# Altium schematic sheet OpenBLC_TPS62152.SchDoc(OpenBLC_TPS62152)
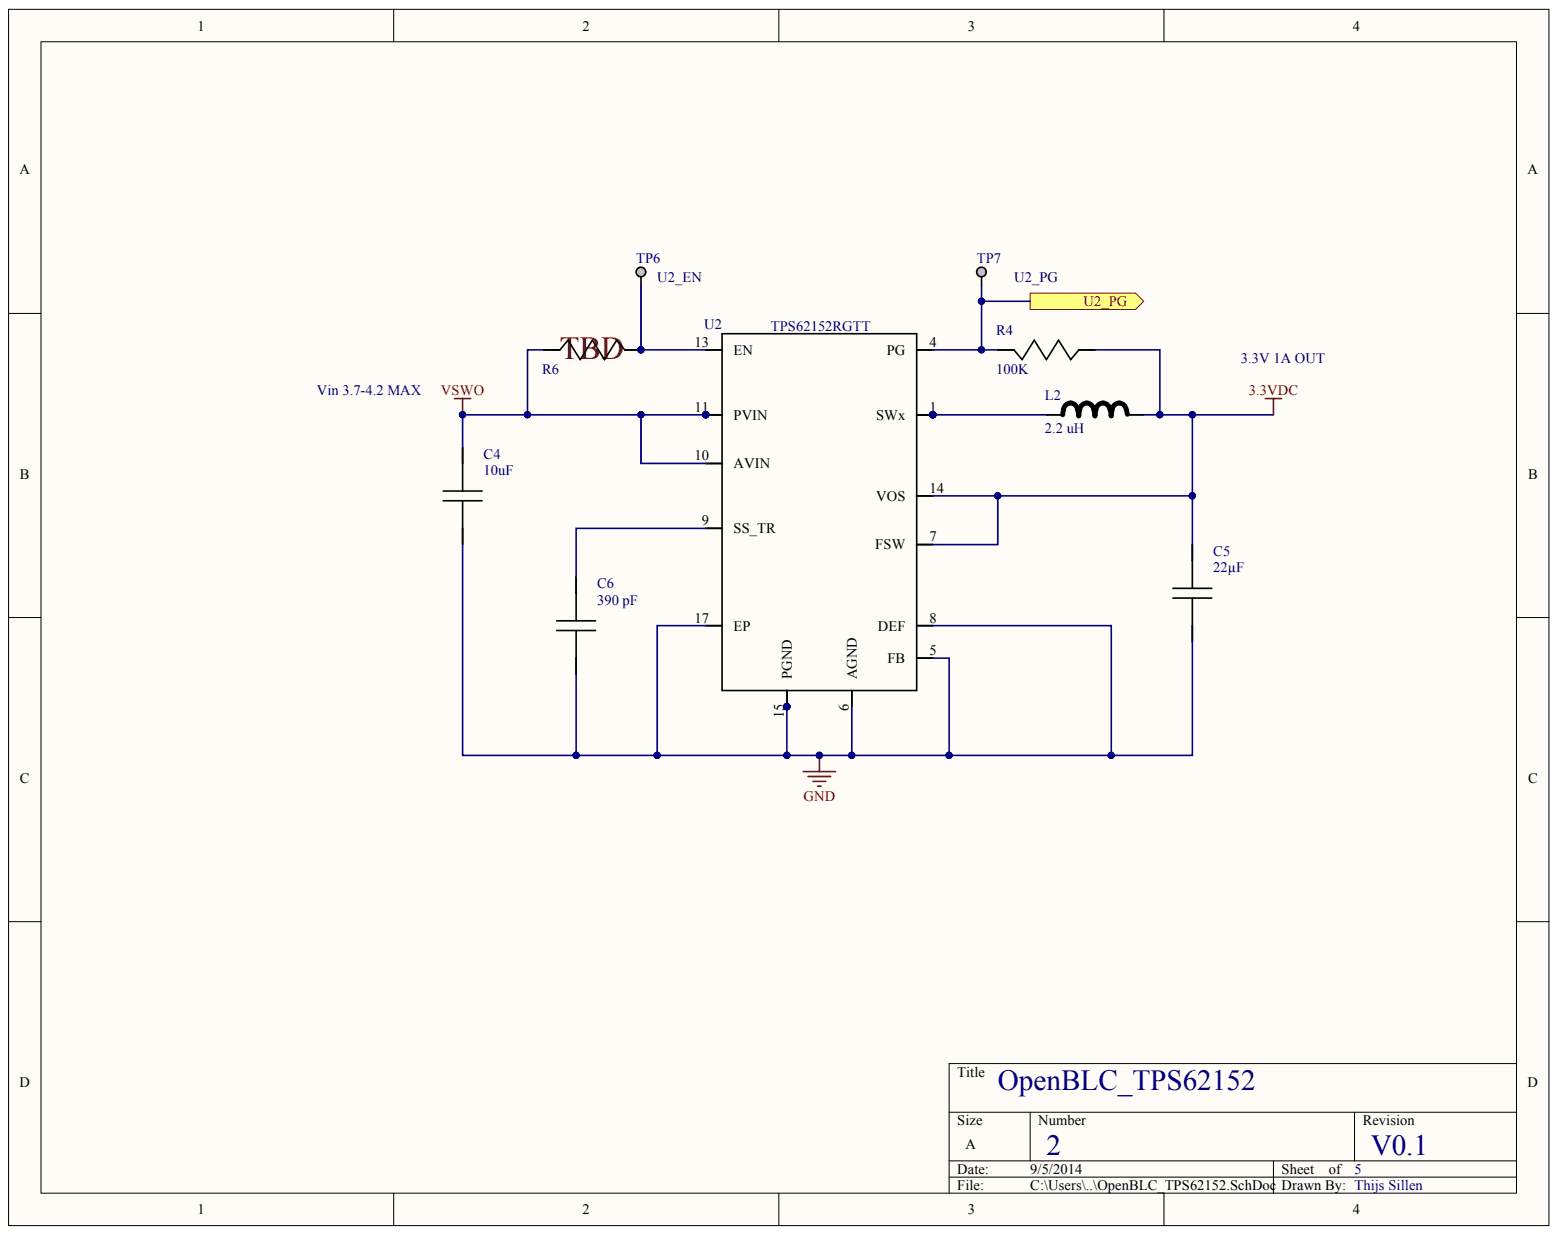
[diagram p0: OpenBLC_TPS62152.SchDoc]

## Parts
C4  Capacitance: 10uF  [0805_CAP]  (hidden comment: GRM21BR61A106KE19L)
C5  Capacitance: 22µF  [0805_CAP]  (hidden comment: C2012X5R0J226M125AC)
C6  GRM033R71C391KA01D  [0603_CAP]  (value not drawn)
L2  Inductantie: 2.2 uH  [SDR0403]  (hidden comment: SDR0403-2R2ML)
R4  Resistance: 100K  [0402_RES]  (hidden comment: CRCW0402100KFKEDHP)
R6  0805_RES  [0805_RES]  (value not drawn)
TP6  U2_EN  [Via_TP]
TP7  U2_PG  [Via_TP]  (value not drawn)
U2  TPS62152RGTT  [TPS62152RGTR]

## Ports
U2_PG

## Nets
3.3VDC: C5.1 L2.1 R4.2 U2.7(FSW) U2.14(VOS)
GND: C4.2 C5.2 C6.2 U2.5(FB) U2.6(AGND) U2.8(DEF) U2.15(PGND) U2.16 U2.17(EP)
VSWO: C4.1 R6.2 U2.10(AVIN) U2.11(PVIN) U2.12
U2_PG: R4.1 TP7.1 U2.4(PG) ; port U2_PG
NetC6_1: C6.1 U2.9(SS_TR)
NetL2_2: L2.2 U2.1(SWx) U2.2 U2.3
NetR6_1: R6.1 TP6.1 U2.13(EN)

## Library data of the parts
C4 = GRM21BR61A106KE19L: MURATA - GRM21BR61A106KE19L - CAPACITOR, CERA, 10UF, 10%, 10V, 10%, SMD; Ceramic Capacitor Case: 0805 ^2012 Metric]; Dielectric Characteristic: X5; Product Range: MURATA - GRM Series; Voltage Rating: 10; Capacitance Tolerance: ± 10%; Component Kind: Standard; ComponentLink1Description: http://www.farnell.com/datasheets/608985.pdf; ComponentLink1URL: http://www.farnell.com/datasheets/608985.pdf; Library Name: Schlib1.SchLib; Manufacturer: MURATA; Manufacturer Part Number: GRM21BR61A106KE19L; MSL: -; Pricing 1: 1=0.042, 250=0.039, 500=0.034, 1000=0.031, 5000=0.022 (EUR); RoHS: YES; Stock 1: 20106; Supplier 1: Farnell; Supplier Part Number 1: 2396552
C5 = C2012X5R0J226M125AC: CAP CER 22UF 6.3V 20% X5R 0805; Manufacturer: TDK Corporation; Applications: General Purpose; Category: Capacitors; Component Kind: Standard; ComponentLink1Description: http://product.tdk.com/en/catalog/datasheets/mlcc_commercial_general_en.pdf; ComponentLink1URL: http://product.tdk.com/en/catalog/datasheets/mlcc_commercial_general_en.pdf; Features: -; Height - Seated (Max): -; Lead Spacing: -; Lead Style: -; Library Name: Schlib1.SchLib; Manufacturer Part Number: C2012X5R0J226M125AC; Mounting Type: Surface Mount, MLCC; Operating Temperature: -55°C ~ 85°C; Package / Case: 0805 (2012 Metric); Packaging: Cut Tape (CT); Pricing 1: 1=0.24, 10=0.204, 100=0.1021, 250=0.07656, 500=0.06762, 1000=0.05878 (EUR); Ratings: -; RoHS: RoHS Compliant; Size / Dimension: 0.079" L x 0.049" W (2.00mm x 1.25mm); Stock 1: 147939; Supplier 1: Digi-Key; Supplier Part Number 1: 445-1422-1-ND; Temperature Coefficient: X5R; Thickness (Max): 0.057" (1.45mm); Tolerance: ±20%; Voltage - Rated: 6.3V
C6 = GRM033R71C391KA01D: Meerlaagse keramische condensatoren MLCC - SMD/SMT 390pF 16 volts 10%; Manufacturer: Murata Electronics; Bedrijfstemperatuurbereik: - 55 C to + 125 C; Breedte behuizing: 0.3 mm; Code behuizing - in: 0201; Code behuizing - mm: 0603; Diëlectrisch: X7R; Fabrikant: Murata; Lengte behuizing: 0.6 mm; Maximale bedrijfstemperatuur: + 125 C; Minimale bedrijfstemperatuur: - 55 C; Nominale spanning: 16 V; Reeks: GRM; Standaard pakket hoeveelheid: 15000; Temperatuur Coëfficiënt / Code: +/- 15 %; Type: General Purpose MLCC; Verpakken: Reel; Verpakking / doos: 0201 (0603 metric); Capaciteit: 390 pF; Category: Meerlaagse keramische condensatoren MLCC - SMD/SMT; Component Kind: Standard; ComponentLink1Description: http://psearch.murata.com/capacitor/product/GRM033R71C391KA01%23.pdf; ComponentLink1URL: http://psearch.murata.com/capacitor/product/GRM033R71C391KA01%23.pdf; Hoogte behuizing: 0.3 mm; Klemtype: SMD/SMT; Library Name: Schlib1.SchLib; Manufacturer Part Number: GRM033R71C391KA01D; Merk: Murata Electronics; Minimum Order Quantity: 1; Nominale spanning DC: 16 V; Pricing 1: 1=0.088, 10=0.011, 100=0.006, 1000=0.005, 2500=0.004, 10000=0.003, 15000=0.003 (EUR); Product: General Type MLCCs; Reeling: True; RoHS: RoHS Compliant; RoHS - Mouser: Y; Stock 1: 6120; Supplier 1: Mouser; Supplier Part Number 1: 81-GRM033R71C391KA1D; Tolerantie: 10 %
L2 = SDR0403-2R2ML: Vaste smoorspoelen 2.2uH 20% SMD 0403; Breedte behuizing: 3.2 mm; Fabrikant: Bourns; Klemtype: SMD/SMT; Lengte behuizing: 4.5 mm; Maximale DC stroom: 2.6 A; Maximale DC weerstand: 47 mOhms; Productcategorie: Inductors; Q minimaal: 28; Standaard pakket hoeveelheid: 2000; Verpakken: Reel; Zelfresonantiefrequentie: 80 MHz; Category: Vaste smoorspoelen; Component Kind: Standard; ComponentLink1Description: http://www.bourns.com/data/global/pdfs/sdr0403.pdf; ComponentLink1URL: http://www.bourns.com/data/global/pdfs/sdr0403.pdf; Library Name: Schlib1.SchLib; Manufacturer: Bourns; Manufacturer Part Number: SDR0403-2R2ML; Merk: Bourns; Minimum Order Quantity: 1; Pricing 1: 1=0.378, 10=0.279, 100=0.257, 250=0.244, 500=0.202, 1000=0.171, 2000=0.169 (EUR); Reeks: SDR0403; Reeling: True; RoHS: RoHS Compliant; RoHS - Mouser: Y; Stock 1: 11347; Supplier 1: Mouser; Supplier Part Number 1: 652-SDR0403-2R2ML; Tolerantie: 20 %
R4 = CRCW0402100KFKEDHP: VISHAY DRALORIC - CRCW0402100KFKEDHP - RESISTOR, POWER, 100K, 0.125W, 1%; External Width: 1; Power Rating: 125; Product Range: VISHAY - CRCW Series; Resistor Case Style: 0402 [1005 Metric]; Resistor Element Material: Thick Film; Resistor Mounting: SMD<!--FANDB--><div class="features-and-benefits-container"></div>; SVHC: No SVHC (16-Dec-2013); Temperature Coefficient: ± 100; Voltage Rating: 50; Component Kind: Standard; ComponentLink1Description: http://www.farnell.com/datasheets/1703058.pdf; ComponentLink1URL: http://www.farnell.com/datasheets/1703058.pdf; Library Name: Schlib1.SchLib; Manufacturer: VISHAY DRALORIC; Manufacturer Part Number: CRCW0402100KFKEDHP; MSL: MSL 1 - Unlimited; Packaging: Cut Tape; Pricing 1: 25=0.032, 100=0.024, 500=0.016, 1000=0.011, 10000=0.008 (EUR); Resistance Tolerance: ± 1%; RoHS: Y-EX; Stock 1: 20841; Supplier 1: Farnell; Supplier Part Number 1: 1738877
TP6 TP7 = TESTPOINT: TESTPOINT SCOPE GRABBER; Manufacturer: Keystone Electronics; Manufacturer Part Number: 5010; Reel: TH; Component Kind: Standard; Library Name: vh_altium_library.intLib; Supplier 1: Digi-Key; Supplier Part Number 1: 5010K-ND
U2 = TPS62152RGTT: Manufacturer: Texas Instruments; Current - Output: 1A; Frequency - Switching: 2.5MHz; Number of Outputs: 1; Output Type: Fixed; Synchronous Rectifier: Yes; Voltage - Input: 3 V ~ 17 V; Voltage - Output: 3.3V; Category: Integrated Circuits (ICs); Component Kind: Standard; ComponentLink1Description: http://www.ti.com/lit/ds/symlink/tps62151.pdf; ComponentLink1URL: http://www.ti.com/lit/ds/symlink/tps62151.pdf; Description: TPS62152RGTT; Library Name: Schlib1.SchLib; Mounting Type: Surface Mount; Operating Temperature: -40°C ~ 85°C; Package / Case: 16-VFQFN Exposed Pad; Packaging: Cut Tape (CT); Pricing 1: 1=2.99, 10=2.696, 25=2.4148, 100=2.1715 (USD); RoHS: RoHS Compliant; Stock 1: 1028; Supplier 1: Digi-Key; Supplier Device Package: 16-QFN (3x3); Supplier Part Number 1: 296-30009-1-ND; Type: Step-Down (Buck)
R6 = 0805_RES: Component Kind: Standard; Library Name: Schlib1.SchLib
